# Altium schematic sheet MEMORY.SchDoc(MEMORY)
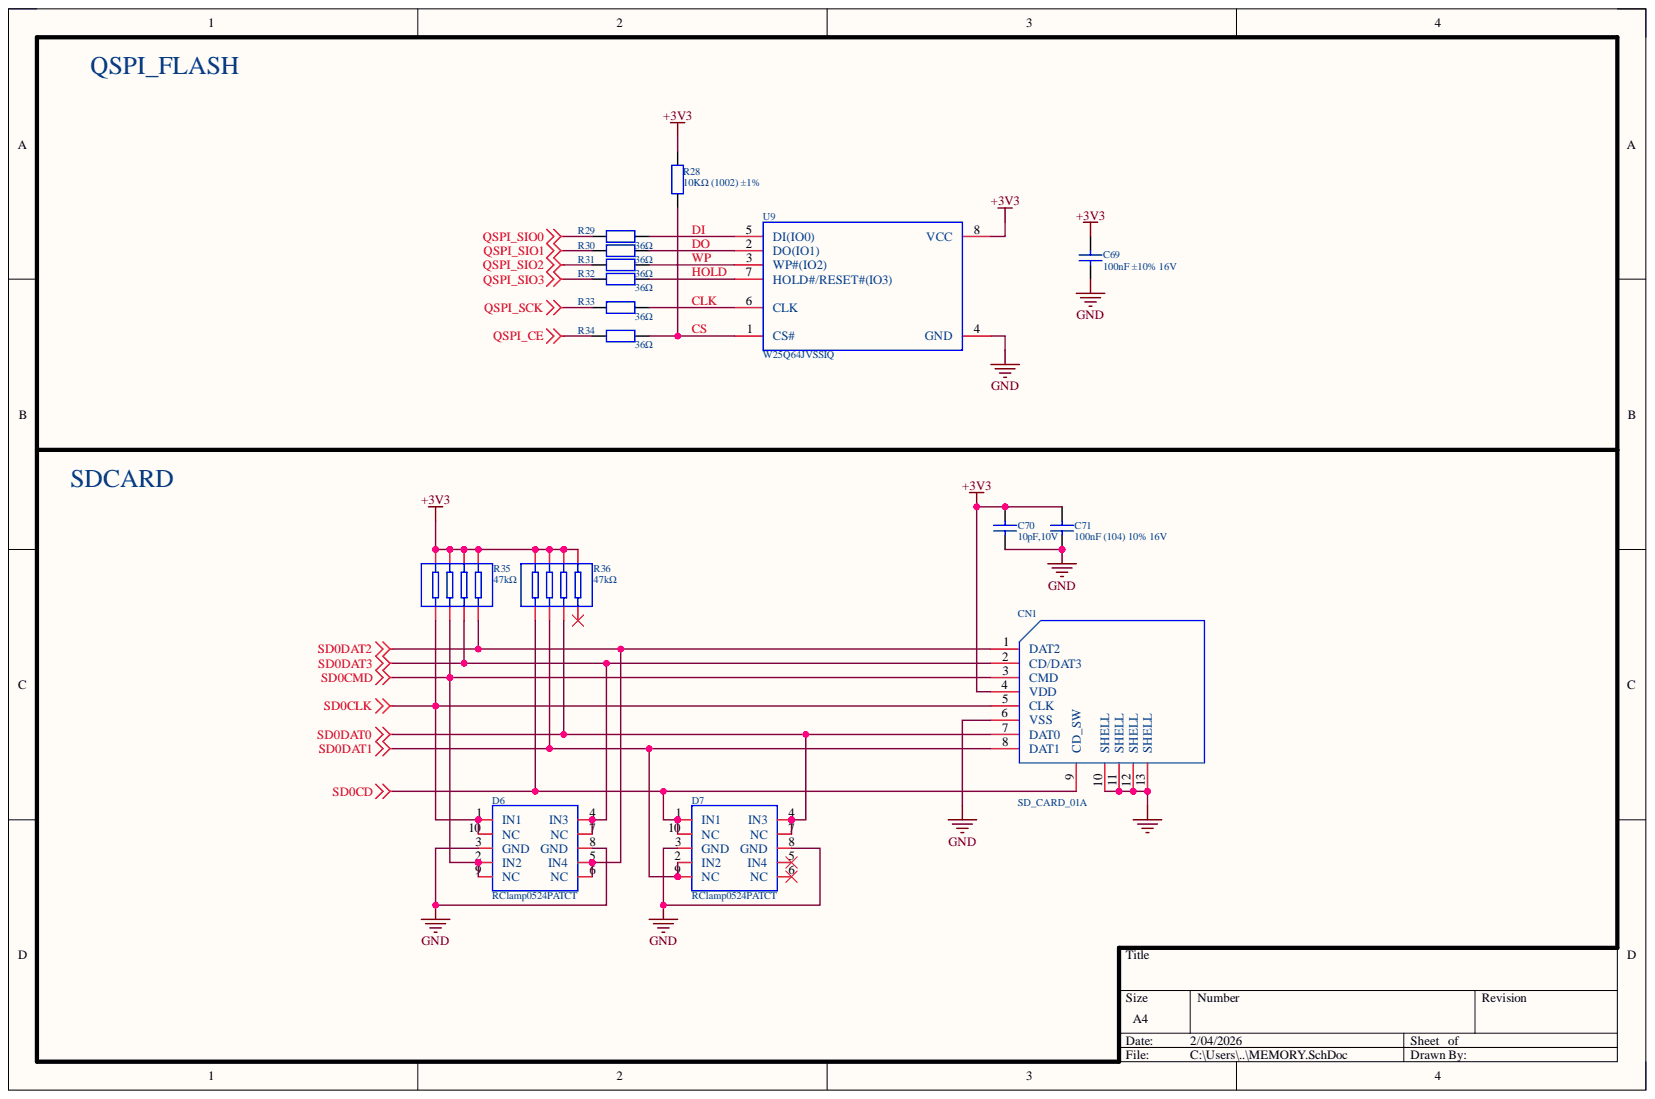
[diagram p0: MEMORY.SchDoc]

## Parts
C69  100nF ±10% 16V  Capacitance: 100nF  [C0201]
C70  10pF,10V  [C0402]
C71  100nF (104) 10% 16V  [C0402]
CN1  SD_CARD_01A  [SD_Card_TF]
D6  RClamp0524PATCT  [SLP2510P8_L2.5-W1.0-P0.50-BL]
D7  RClamp0524PATCT  [SLP2510P8_L2.5-W1.0-P0.50-BL]
R28  10KΩ (1002) ±1%  [R0402]
R29  36Ω  [R0402]
R30  36Ω  [R0402]
R31  36Ω  [R0402]
R32  36Ω  [R0402]
R33  36Ω  [R0402]
R34  36Ω  [R0402]
R35  47kΩ  [RES-ARRAY-SMD_0402-8P-L2.0-W1.0-BL]
R36  47kΩ  [RES-ARRAY-SMD_0402-8P-L2.0-W1.0-BL]
U9  W25Q64JVSSIQ  [SOP-8_L5.3-W5.2-P1.27-LS7.9-BL]

## Nets
+3V3: C69.2 C70.1 C71.1 CN1.4(VDD) R28.2 R35.5 R35.6 R35.7 R35.8 R36.5 R36.6 R36.7 R36.8 U9.8(VCC)
GND: C69.1 C70.2 C71.2 CN1.6(VSS) CN1.10(SHELL) CN1.11(SHELL) CN1.12(SHELL) CN1.13(SHELL) D6.3(GND) D6.8(GND) D7.3(GND) D7.8(GND) U9.4(GND)
QSPI_CE: R34.1
QSPI_SCK: R33.1
QSPI_SIO0: R29.1
QSPI_SIO1: R30.1
QSPI_SIO2: R31.1
QSPI_SIO3: R32.1
SD0CD: CN1.9(CD_SW) D7.1(IN1) D7.10(NC) R36.1
SD0CLK: CN1.5(CLK) D6.1(IN1) D6.10(NC) R35.1
SD0CMD: CN1.3(CMD) D6.2(IN2) D6.9(NC) R35.2
SD0DAT0: CN1.7(DAT0) D7.4(IN3) D7.7(NC) R36.3
SD0DAT1: CN1.8(DAT1) D7.2(IN2) D7.9(NC) R36.2
SD0DAT2: CN1.1(DAT2) D6.5(IN4) D6.6(NC) R35.4
SD0DAT3: CN1.2(CD/DAT3) D6.4(IN3) D6.7(NC) R35.3
CLK: R33.2 U9.6(CLK)
CS: R28.1 R34.2 U9.1(CS#)
DI: R29.2 U9.5(DI(IO0))
DO: R30.2 U9.2(DO(IO1))
HOLD: R32.2 U9.7(HOLD#/RESET#(IO3))
WP: R31.2 U9.3(WP#(IO2))

Not connected: D7 5(IN4) 6(NC); R36 4

## Library data of the parts
C69 = CAP-000030-01: 0201,100nF ±10% 16V，X7R; Legacy Part Number: 151-10004022; Manufacturer: muRata; Manufacturer Part Number 1: GRM033Z71C104KE14D; Dialectric: X7R; Notes: ANY EQUIVALENT; ClassName: MCU; Component Kind: Standard; ComponentLink1Description: New link; ComponentLink1URL: https://item.szlcsc.com/698285.html?fromZone=l_c__%2522catalog%2522&spm=sc.cid.xh3.zy.n___sc.gb.xh1.zy.l&lcsc_vid=FgdZAQZURgVfVlRQQQNbBAcFQVZYX1NeQ1BYX10ARFkxVlNSQ1FZUVReQVdaUjsOAxUeFF5JWAgaAglIBBsCBBcFWQIBCks%3D; Library Name: RA8P1.SCHLIB; Manufacturer 1: muRata; Manufacturer 3: AVX; Manufacturer Part Number 3: 0402YC104KAT2A; Mfg: muRata; Mfg P/N: GRM033Z71C104KE14D; Mfg Part Number: GRM033Z71C104KE14D; Package: 0402; PN: GRM033Z71C104KE14D; Supplier 1: Arrow Electronics; Supplier 2: Digi-Key; Supplier 3: Newark; Supplier 4: Mouser; Supplier Part Number 1: CC0402KRX7R8BB104; Supplier Part Number 2: 311-2077-1-ND; Supplier Part Number 3: 40AJ6370; Supplier Part Number 4: 603-CC402KRX7R8BB104; Tolerance: 10%; Voltage: 16V
C70 = 0402 4.7uF (475) 20% 10V: 贴片电容; Component Kind: Standard; ComponentLink1Description: 供应商链接; ComponentLink1URL: http://www.szlcsc.com/product/details_24469.html; Library Name: RA8P1.SCHLIB; Package: 0402; Supplier: LC; SuppliersPartNumber: C23733
C71 = 0402 100nF (104) 10% 16V: 贴片电容; Component Kind: Standard; ComponentLink1Description: 供应商链接; ComponentLink1URL: https://item.szlcsc.com/42839.html; Library Name: RA8P1.SCHLIB; Package: 0402; Supplier: LC; SuppliersPartNumber: C1525
CN1 = SD_CARD_01A: 自弹式SD卡插槽，带CD检测; Component Kind: Standard; Library Name: ra8p1_v0.1.SCHLIB
D6 D7 = RClamp0524PATCT: ESD; Manufacturer: SEMTECH; Add into BOM: yes; Breakdown Voltage: 6V; Device: RClamp0524PATCT_C40960; Maximum Clamping Voltage: 15V; Number of Lines: 四路; Peak Pulse Power Dissipation (Ppp): 150W; Reverse Stand-Off Voltage (Vrwm): 5V; Component Kind: Standard; Convert to PCB: yes; Datasheet: https://atta.szlcsc.com/upload/public/pdf/source/20150124/1457707260739.pdf; JLCPCB Part Class: Extended Part; LCSC Part Name: RClamp0524PATCT; Library Name: ra8p1_v0.2.SCHLIB; Manufacturer Part: RClamp0524PATCT; Operating Temperature: -55℃~+125℃@(Tj); PCB3D: SLP2510-8P_L2.5-W1.0-H0.5; Supplier: LCSC; Supplier Footprint: DFN-10(1x2.5); Supplier Part: C40960; Symbol: RClamp0524PATCT; Type: ESD
R28 = 0402 10KΩ (1002) 1%: 贴片电阻，10K; Component Kind: Standard; ComponentLink1Description: 供应商链接; ComponentLink1URL: https://item.szlcsc.com/26487.html?fromZone=l_b__%2522brand%2522&spm=sc.bid.xh1.zy.n&lcsc_vid=FANcVFBQFQMNX1cFFAdZVVZXEgdeAgdWFlQNUVAHT1ExVlNSRFhXVlJQQFlYVzsOAxUeFF5JWA0HFBBIBBsCBBcFWQIBCksEBQAAA0tfTk8GEwkK; Library Name: RA8P1.SCHLIB; Package: 0402; Supplier: LC; SuppliersPartNumber: C25744
R29-R34 = 0402_36R: 贴片电阻; Component Kind: Standard; ComponentLink1Description: 供应商链接; ComponentLink1URL: https://item.szlcsc.com/58714.html?fromZone=s_s__%252236r%2522&spm=sc.gbn.xh13.zy.n&lcsc_vid=RFBdUgFWTgRXA1VWFlVXVlZfEVRbAgVXRAcPX1ZSE1YxVlNQQVZfXlJSQFdcXjsOAxUeFF5JWBIBSRccGwIdBEoFGAxBAAgJFQACSQwSGg0%3D; Library Name: RA8P1.SCHLIB; Package: 0402; Supplier: LC; SuppliersPartNumber: C57678
R35 R36 = 4D02WGJ0473TCE: 0402x4; Manufacturer: UNI-ROYAL(厚声); Add into BOM: yes; Number of Resistors: 4; Power(Watts): 62.5mW; Temperature Coefficient: ±200ppm/℃; Tolerance: ±5%; Component Kind: Standard; Convert to PCB: yes; Datasheet: https://atta.szlcsc.com/upload/public/pdf/source/20191224/C474318_2527498AE30E813171187CCD28401A71.pdf; Device: 4D02WGJ0473TCE; JLCPCB Part Class: Extended Part; LCSC Part Name: 47kΩ ±5%; Library Name: ra8p1_v0.2.SCHLIB; Manufacturer Part: 4D02WGJ0473TCE; Number of Pins: 8; PCB3D: R0402-8P_L2.0-W1.0-H0.5; Supplier: LCSC; Supplier Footprint: 0402x4; Supplier Part: C2028; Symbol: 4D02WGJ0473TCE; Value: 47kΩ
U9 = W25Q64JVSSIQ: Manufacturer: WINBOND(华邦); Add into BOM: yes; Block Erase Time(tBE): 150ms@(64KB); Clock Frequency: 133MHz; Data Retention - TDR (Year): 20年; Interface: SPI; Memory Size: 64Mbit; Page Programming Time (Tpp): 3ms; Symbol: W25Q64JVSSIQ_C179171; Voltage - Supply: 2.7V~3.6V; Component Kind: Standard; Convert to PCB: yes; Datasheet: https://item.szlcsc.com/190565.html?fromZone=l_b__%2522brand%2522&spm=sc.bidn.xh1.zy.n___sc.gbn.xh1.zy.p&lcsc_vid=QlJeVQdeRVQMXgVSQlgLUgFXQAIMXwVQEVZfBVQFEwcxVlNQQVZfX1deR1JeVDsOAxUeFF5JWBIBSRccGwIdBEoFGAxBAAgJFQACSQwSGg0%3D; Device: W25Q64JVSSIQ; JLCPCB Part Class: Extended Part; LCSC Part Name: W25Q64JVSSIQ; Library Name: RA8P1.SCHLIB; Manufacturer Part: W25Q64JVSSIQ; Operating Temperature: -40℃~+85℃; PCB3D: SOP-8_L5.3-W5.2-P1.27-LS7.9-BL; Supplier: LCSC; Supplier Footprint: SOIC-8-208mil; Supplier Part: C179171
